# Altium schematic sheet SM-Relay.SchDoc(SM-Relay)
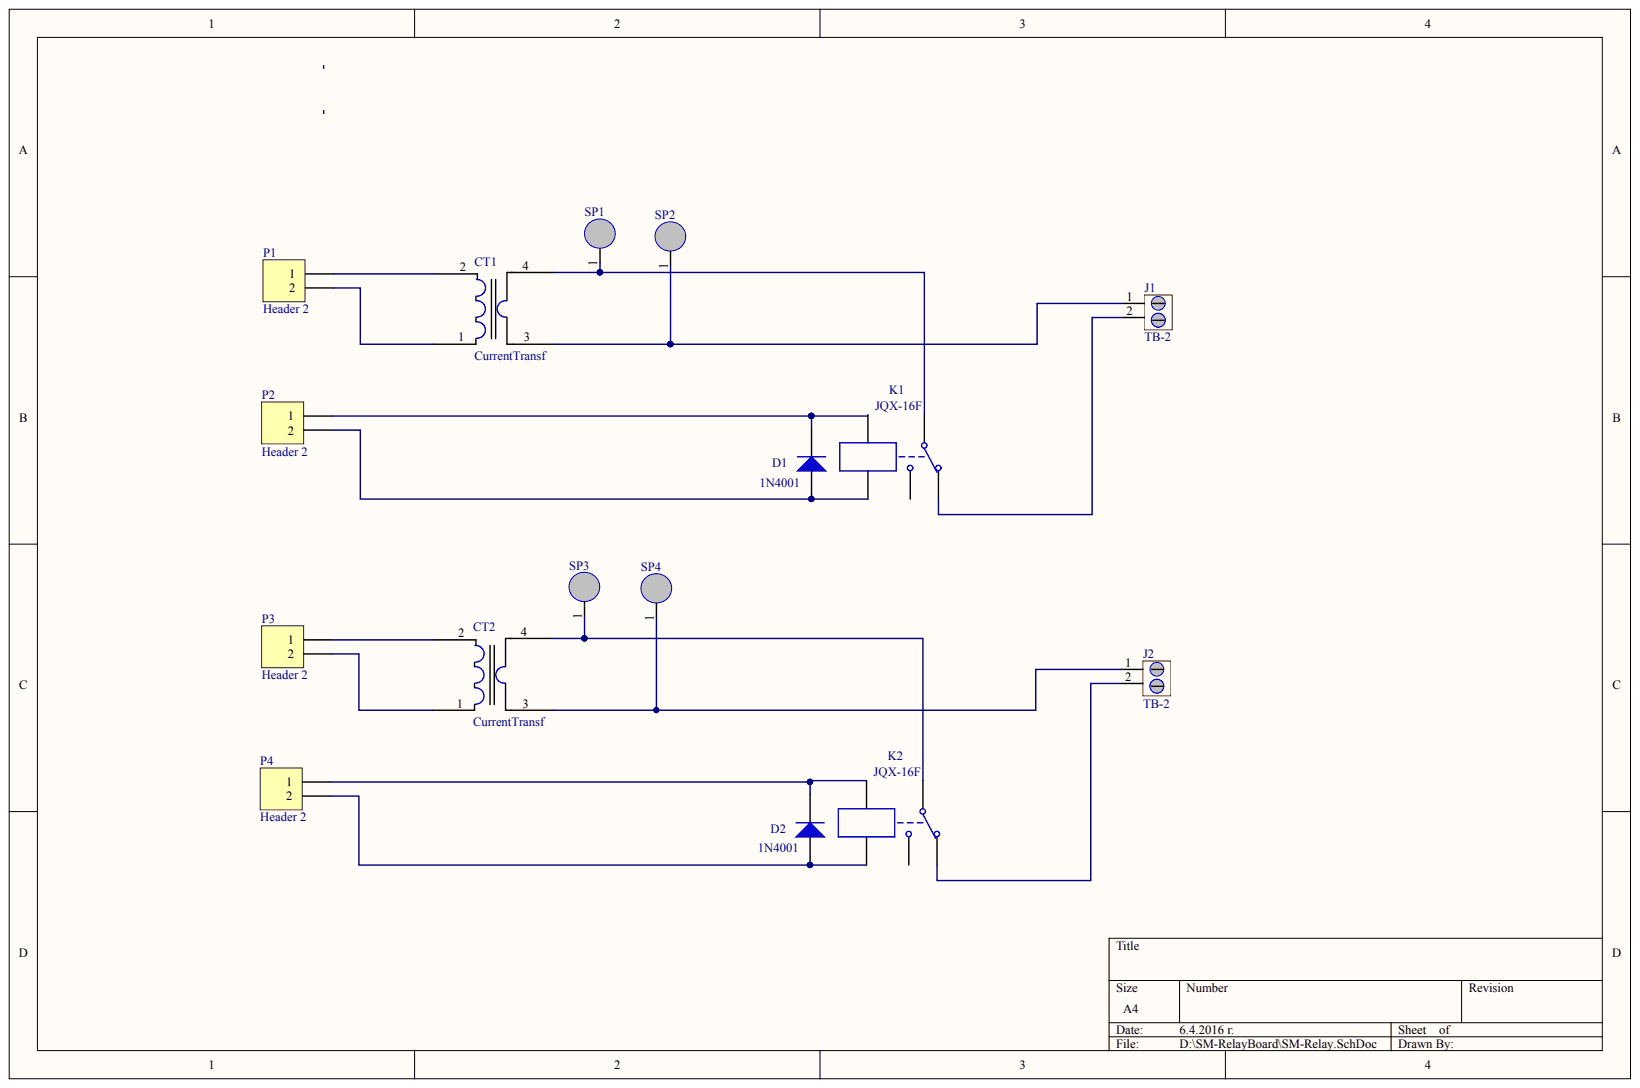
[diagram p0: SM-Relay.SchDoc]

## Parts
CT1  CurrentTransf
CT2  CurrentTransf
D1  1N4001
D2  1N4001
J1  TB-2
J2  TB-2
K1  JQX-16F
K2  JQX-16F
P1  Header 2
P2  Header 2
P3  Header 2
P4  Header 2
SP1  SP-1
SP2  SP-2
SP3  SP-3
SP4  SP-4

## Nets
NetCT1_1: CT1.1 P1.2
NetCT1_2: CT1.2 P1.1
NetCT1_3: CT1.3 J1.1 SP2.1
NetCT1_4: CT1.4 K1.1 SP1.1
NetCT2_1: CT2.1 P3.2
NetCT2_2: CT2.2 P3.1
NetCT2_3: CT2.3 J2.1 SP4.1
NetCT2_4: CT2.4 K2.1 SP3.1
NetD1_1: D1.1 K1.5 P2.2
NetD1_2: D1.2 P2.1
NetD2_1: D2.1 K2.5 P4.2
NetD2_2: D2.2 K2.4 P4.1
NetJ1_2: J1.2 K1.2
NetJ2_2: J2.2 K2.2
N00018:
N00019:
N00020:

Not connected: K1 3 4; K2 3

## Library data of the parts
D1 D2 = (no symbol name): Manufacturer: On Semi; Mfr.PN#: 1N4001G; Suppl.PN#: 1458986; Published: 8-Jun-2000; LatestRevisionDate: 17-Jul-2002; LatestRevisionNote: Re-released for DXP Platform.; DatasheetVersion: 1998; PackageVersion: Aug-1999; PackageReference: Case 59-10; PackageDescription: Diode, Axial; Body 5.2 x 2.7 mm (LxDia. max), Lead Dia. 0.86 mm (max); Code_JEDEC: DO-204-AL; ComponentLink1Description: Manufacturer Link; ComponentLink1URL: http://www.fairchildsemi.com/; ComponentLink2Description: Datasheet; ComponentLink2URL: http://www.fairchildsemi.com/ds/1N%2F1N4001.pdf; Supplier: Farnel; Price: .02; Publisher: Altium Limited
K1 K2 = (no symbol name): Manufacturer: YUEQING HENGWEI Electronics CO,Ltd; Mfr.PN#: JQX-16F(T91)30A1CDC5; Published: 24-Mar-1999; LatestRevisionDate: 17-Jul-2002; LatestRevisionNote: Re-released for DXP Platform.; PackageReference: MODULE5B; Supplier: Shanghai Shinvo Electric Co.Ltd; Price: .2; Publisher: Altium Limited
CT1 CT2 J1 J2 P1-P4 SP1-SP4 = (no symbol name)
  P1-P4: LatestRevisionDate: 17-Jul-2002; LatestRevisionNote: Re-released for DXP Platform.; Publisher: Altium Limited
